# Altium schematic sheet solenoid_mosfets.SchDoc
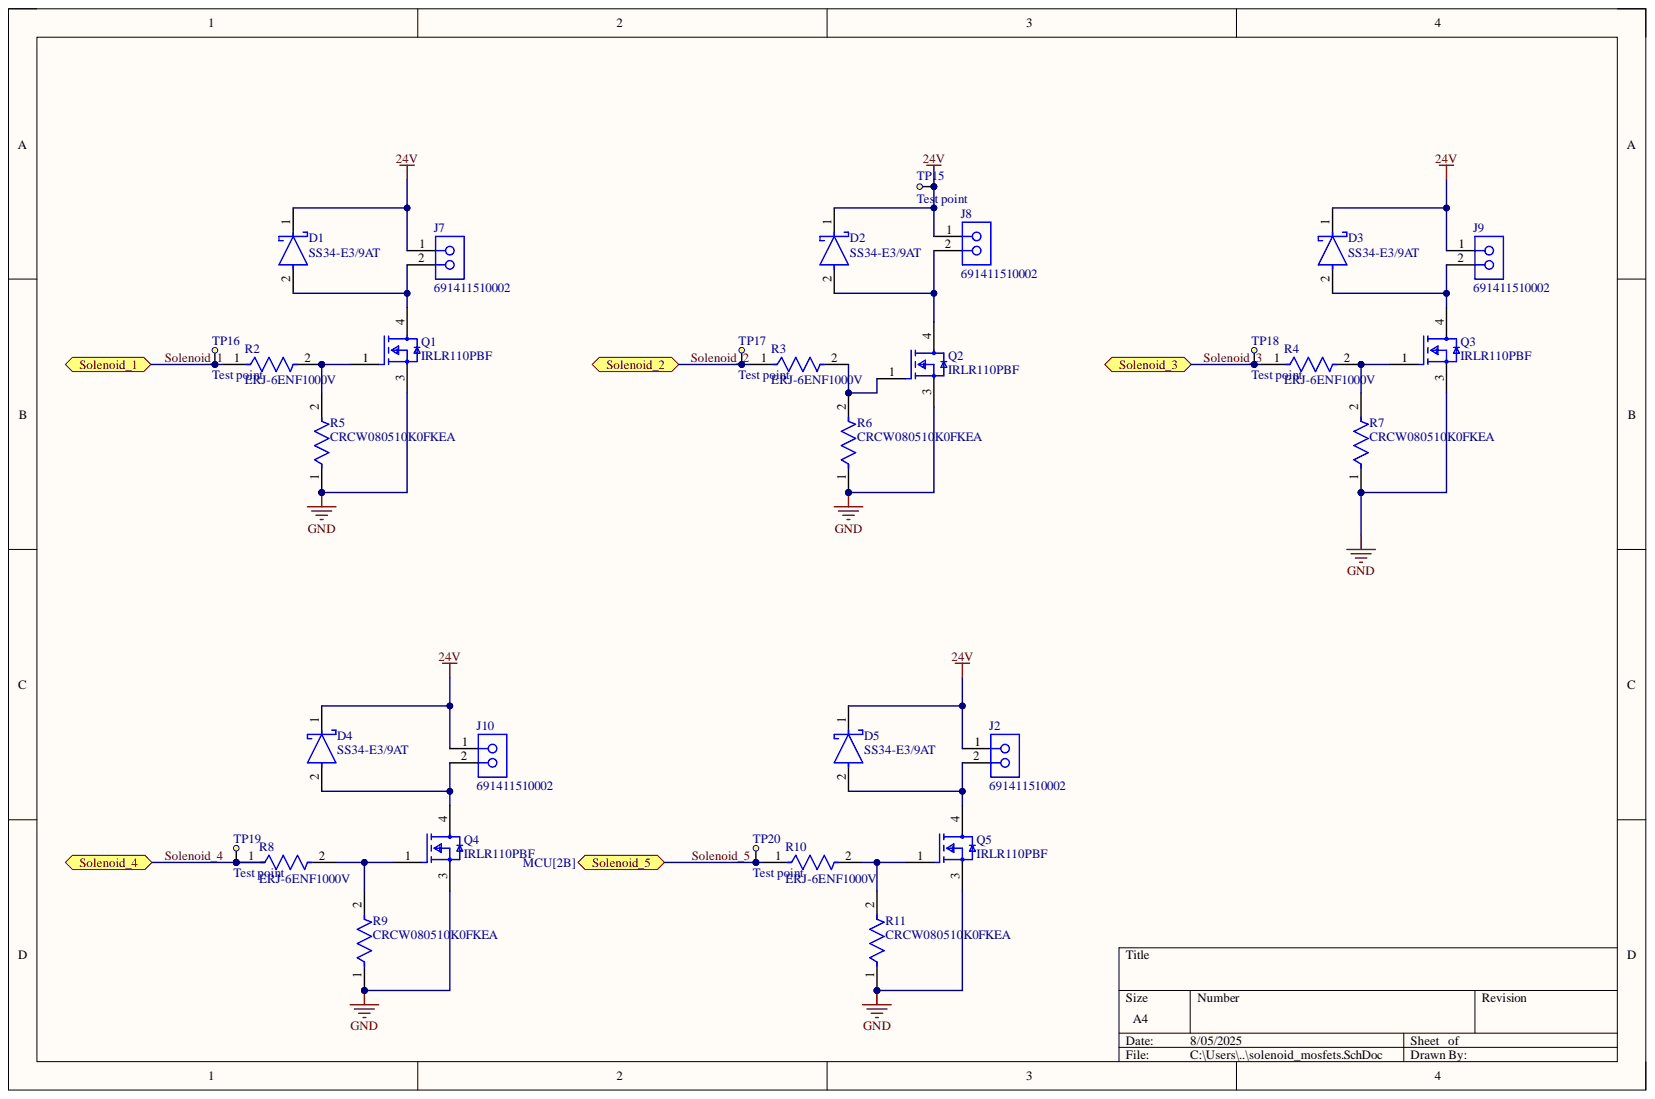
[diagram p0: solenoid_mosfets.SchDoc]

## Parts
D1  SS34-E3/9AT  [FP-SMC-MFG]
D2  SS34-E3/9AT  [FP-SMC-MFG]
D3  SS34-E3/9AT  [FP-SMC-MFG]
D4  SS34-E3/9AT  [FP-SMC-MFG]
D5  SS34-E3/9AT  [FP-SMC-MFG]
J2  691411510002  [691411510002]
J7  691411510002  [691411510002]
J8  691411510002  [691411510002]
J9  691411510002  [691411510002]
J10  691411510002  [691411510002]
Q1  IRLR110PBF  [FP-E19-0649-MFG]
Q2  IRLR110PBF  [FP-E19-0649-MFG]
Q3  IRLR110PBF  [FP-E19-0649-MFG]
Q4  IRLR110PBF  [FP-E19-0649-MFG]
Q5  IRLR110PBF  [FP-E19-0649-MFG]
R2  ERJ-6ENF1000V  [FP-ERJ6EN-MFG]
R3  ERJ-6ENF1000V  [FP-ERJ6EN-MFG]
R4  ERJ-6ENF1000V  [FP-ERJ6EN-MFG]
R5  CRCW080510K0FKEA  [FP-CRCW0805-e3-IPC_A]
R6  CRCW080510K0FKEA  [FP-CRCW0805-e3-IPC_A]
R7  CRCW080510K0FKEA  [FP-CRCW0805-e3-IPC_A]
R8  ERJ-6ENF1000V  [FP-ERJ6EN-MFG]
R9  CRCW080510K0FKEA  [FP-CRCW0805-e3-IPC_A]
R10  ERJ-6ENF1000V  [FP-ERJ6EN-MFG]
R11  CRCW080510K0FKEA  [FP-CRCW0805-e3-IPC_A]
TP15  Test point  [Test_point]
TP16  Test point  [Test_point]
TP17  Test point  [Test_point]
TP18  Test point  [Test_point]
TP19  Test point  [Test_point]
TP20  Test point  [Test_point]

## Ports
Solenoid_1, Solenoid_2, Solenoid_3, Solenoid_4, Solenoid_5

## Nets
24V: D1.1 D2.1 D3.1 D4.1 D5.1 J2.1 J7.1 J8.1 J9.1 J10.1 TP15.TP?
GND: Q1.3 Q2.3 Q3.3 Q4.3 Q5.3 R5.1 R6.1 R7.1 R9.1 R11.1
Solenoid_1: R2.1 TP16.TP? ; port Solenoid_1
Solenoid_2: R3.1 TP17.TP? ; port Solenoid_2
Solenoid_3: R4.1 TP18.TP? ; port Solenoid_3
Solenoid_4: R8.1 TP19.TP? ; port Solenoid_4
Solenoid_5: R10.1 TP20.TP? ; port Solenoid_5
NetD1_2: D1.2 J7.2 Q1.4
NetD2_2: D2.2 J8.2 Q2.4
NetD3_2: D3.2 J9.2 Q3.4
NetD4_2: D4.2 J10.2 Q4.4
NetD5_2: D5.2 J2.2 Q5.4
NetQ1_1: Q1.1 R2.2 R5.2
NetQ2_1: Q2.1 R3.2 R6.2
NetQ3_1: Q3.1 R4.2 R7.2
NetQ4_1: Q4.1 R8.2 R9.2
NetQ5_1: Q5.1 R10.2 R11.2
~{}:

## Library data of the parts
D1-D5 = CMP-02539-000066-1: DIODE SCHOTTKY 40V 3A DO214AB; Element Configuration: Single; Forward Voltage: 500mV; Max Reverse Current: 500uA; Max Surge Current: 100A; Average Rectified Current: 3A; Case/Package: SMC; Component Kind: Standard; Forward Current: 3A; Library Name: Altium Content Vault; Max Operating Temperature: 125°C; Max Repetitive Reverse Voltage (Vrrm): 40V; Max Reverse Leakage Current: 500uA; Max Reverse Voltage (DC): 40V; Min Operating Temperature: -55°C; Mount: Surface Mount; Number of Pins: 2; Package Quantity: 3500; Packaging: Tape and Reel; Peak Non-Repetitive Surge Current: 100A; Radiation Hardening: No; RoHS Compliant: Yes; Series: -
J2 J7-J10 = CMP-1502-03078-2: NexarPartId: 105952432; Current Rating: 10A; Number of Contacts: 2; Voltage Rating: 300V; Component Kind: Standard; Height: 14mm; Library Name: Altium Content Vault; Max Operating Temperature: 120°C; Min Operating Temperature: -30°C; Number of Rows: 1
Q1-Q5 = CMP-00030-46527327-1: IRLR110PBF Mosfet; Power; N-ch; Vdss 100V; Rds(on) 0.54 Ohm; Id 4.3A; TO-252AA; Pd 25W; Vgs +/-10V; Drain to Source Resistance: 540mΩ; Element Configuration: Single; Fall Time: 17ns; Gate to Source Voltage (Vgs): 10V; Input Capacitance: 250pF; Max Power Dissipation: 2.5W; Number of Channels: 1; Rise Time: 47ns; Threshold Voltage: 2V; Turn-Off Delay Time: 16ns; Turn-On Delay Time: 9.3ns; Case/Package: DPAK; Component Kind: Standard; Continuous Drain Current (ID): 4.3A; Current Rating: 4.3A; Drain to Source Breakdown Voltage: 100V; Drain to Source Voltage (Vdss): 100V; Height: 2.39mm; Lead Free: Lead Free; Length: 6.73mm; Library Name: Altium Content Vault; Max Operating Temperature: 150°C; Min Operating Temperature: -55°C; Mount: Surface Mount; NexarPartId: 46527327; Number of Elements: 1; Number of Pins: 3; Power Dissipation: 2.5W; Radiation Hardening: No; Rds On Max: 540mΩ; REACH SVHC: Unknown; Resistance: 540MΩ; RoHS: Compliant; Schedule B: 8541290080, 8541290080; Voltage Rating (DC): 100V; Weight: 1.437803g; Width: 6.22mm
R2-R4 R8 R10 = CMP-2001-04374-6: RES SMD 100 OHM 1% 1/8W 0805; Max Power Dissipation: 125mW; Resistance: 100R; Temperature Coefficient: 100ppm/°C; Voltage Rating: 150V; Case Code (Imperial): 0805; Case Code (Metric): 2012; Case/Package: 0805; Component Kind: Standard; Construction: Rectangular; Contact Plating: Tin; Depth: 1.25mm; Features: Automotive AEC-Q200; Height: 0.6mm; Lead Free: Lead Free; Length: 2mm; Library Name: Altium Content Vault; Max Operating Temperature: 155°C; Military Standard: Not; Min Operating Temperature: -55°C; Mount: Surface Mount; Number of Pins: 2; Number of Terminations: 2; Package Quantity: 1; Packaging: Tape and Reel; Power Rating: 125mW; Radiation Hardening: No; REACH SVHC: No SVHC; Resistance Tolerance: 1%; Resistor Type: Thick Film; RoHS Compliant: Yes; Series: ERJ; Size Code: 0805; Technology: THICK FILM; Termination: SMD/SMT; Tolerance: 1%; Voltage Rating (DC): 150V; Width: 1.2mm
R5-R7 R9 R11 = CMP-2001-04908-2: RES Thick Film, 10kΩ, 1%, 0.125W, 100ppm/°C, 0805; Power Rating: 125mW; Resistance: 10kR; Voltage Rating: 150V; Case Code (Imperial): 0805; Case Code (Metric): 2012; Case/Package: 0805; Component Kind: Standard; Composition: Thick Film; Contact Plating: Tin; Depth: 1.25mm; Height: 0.45mm; Lead Free: Lead Free; Length: 2mm; Library Name: Altium Content Vault; Max Operating Temperature: 155°C; Military Standard: Not; Min Operating Temperature: -55°C; Package Quantity: 5000; Packaging: Tape and Reel; Radiation Hardening: No; Temperature Coefficient: 100ppm/°C; Termination: SMD/SMT; Tolerance: 1%; Voltage Rating (DC): 150V; Weight: 0.000194oz; Width: 1.25mm
TP15-TP20 = test_point: Component Kind: Standard; Library Name: SL1.SchLib; Value: Test point
